# Altium schematic sheet Audio_Input_Digitial_Mic.SchDoc
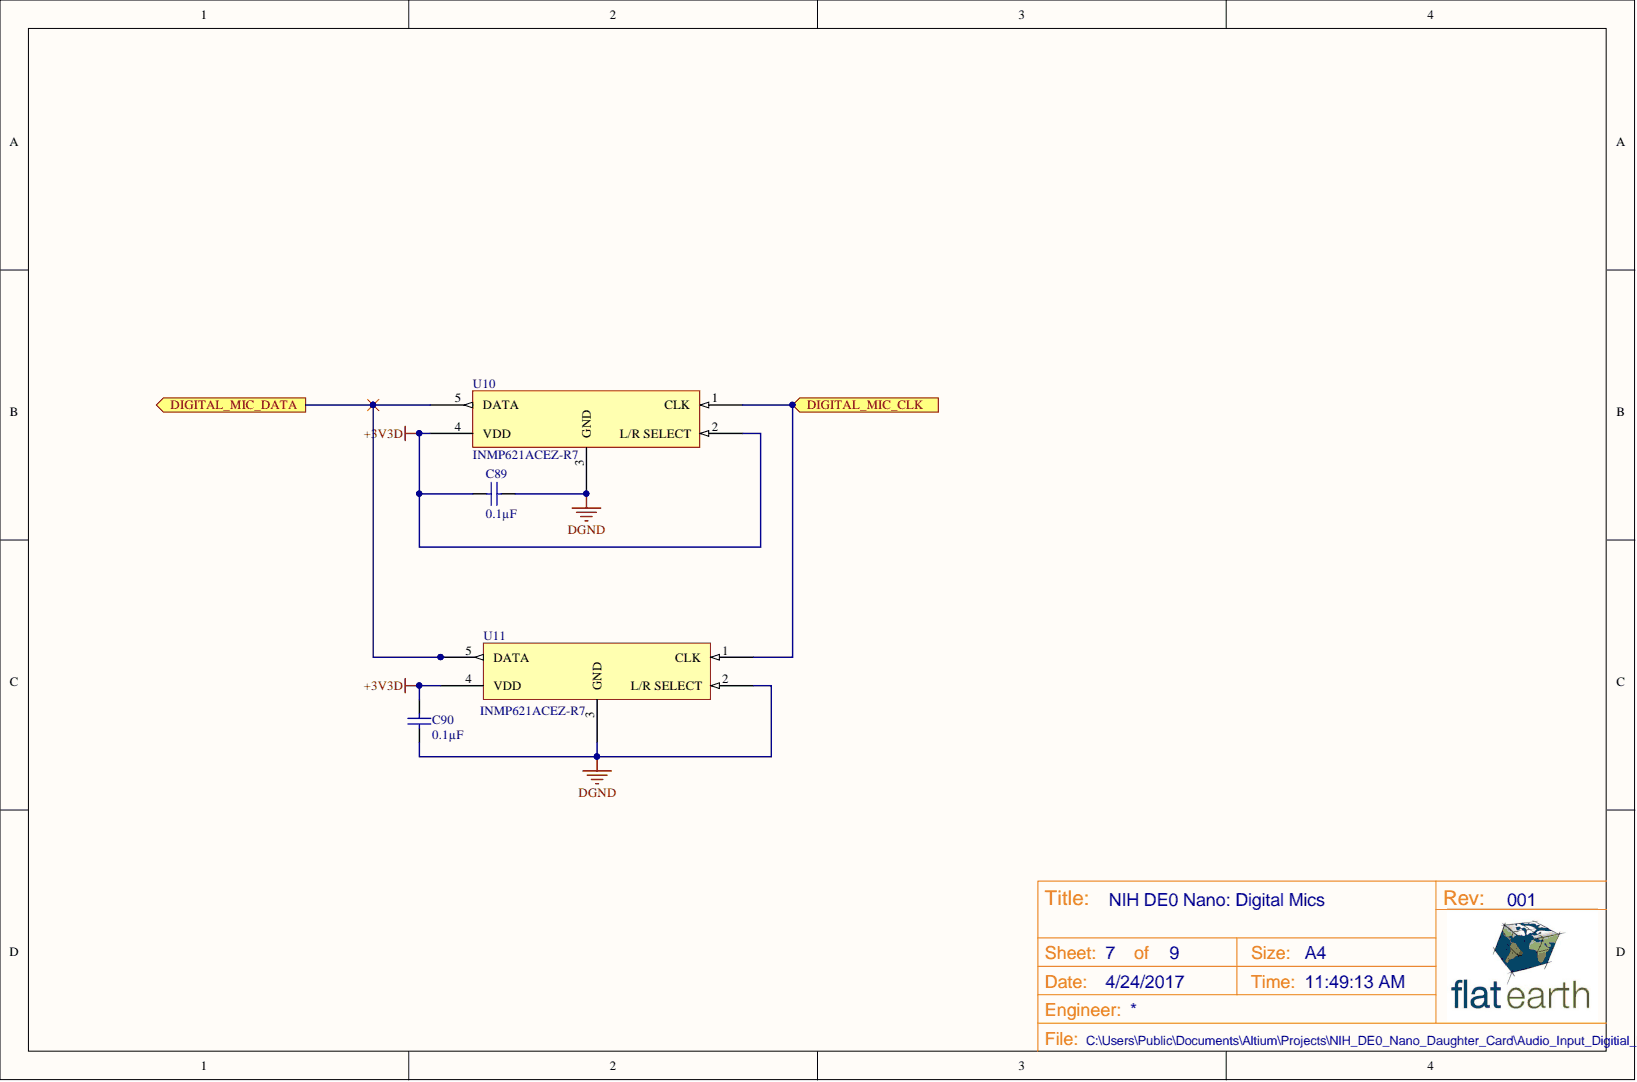
[diagram p0: Audio_Input_Digitial_Mic.SchDoc]

## Parts
C89  Capacitance: 0.1µF  [3-0603_L]  (hidden comment: C1608X7R1H104K)
C90  Capacitance: 0.1µF  [3-0603_L]  (hidden comment: C1608X7R1H104K)
U10  INMP621  Manufacturer Part Number: INMP621ACEZ-R7  [INMP621]
U11  INMP621  Manufacturer Part Number: INMP621ACEZ-R7  [INMP621]

## Ports
DIGITAL_MIC_CLK, DIGITAL_MIC_DATA

## Nets
+3V3D: C89.1 C90.2 U10.2(L/R_SELECT) U10.4(VDD) U11.4(VDD)
DGND: C89.2 C90.1 U10.3(GND) U11.2(L/R_SELECT) U11.3(GND)
DIGITAL_MIC_CLK: U10.1(CLK) U11.1(CLK) ; port DIGITAL_MIC_CLK
DIGITAL_MIC_DATA: U10.5(DATA) U11.5(DATA) ; port DIGITAL_MIC_DATA

## Library data of the parts
C89 C90 = C1608X7R1H104K: CAP CER 0.1UF 50V 10% X7R 0603; Manufacturer: TDK Corporation; Applications: General Purpose; Category: Capacitors; Component Kind: Standard; ComponentLink1Description: http://www.tdk.com/pdf/general_B11.pdf; ComponentLink1URL: http://www.tdk.com/pdf/general_B11.pdf; Features: -; Height - Seated (Max): -; Lead Spacing: -; Lead Style: -; Library Name: Schematic_Components.SchLib; Manufacturer Part Number: C1608X7R1H104K; Mounting Type: Surface Mount, MLCC; Operating Temperature: -55°C ~ 125°C; Package / Case: 0603 (1608 Metric); Packaging: Cut Tape (CT); Pricing 1: 10=0.048, 100=0.022, 250=0.018, 500=0.0154, 1000=0.012 (USD); Ratings: -; RoHS: RoHS Compliant; Size / Dimension: 0.063" L x 0.031" W (1.60mm x 0.80mm); Stock 1: 2442296; Supplier 1: Digi-Key; Supplier Part Number 1: 445-1314-1-ND; Temperature Coefficient: X7R; Thickness (Max): 0.037" (0.95mm); Tolerance: ±10%; Voltage - Rated: 50V
U10 U11 = INMP621: 45Hz ~ 20kHz Digital, PDM Microphone MEMS (Silicon) Omnidirectional (-46dB ±2dB @ 94dB SPL) Solder Pads; Manufacturer: InvenSense; Current - Supply: 1.6mA; Port Location: Bottom; S/N Ratio: 65dB; Seal Rating: None; Shape: Rectangular; Voltage Range: 1.62 ~ 3.63V; Category: Audio Products; Component Kind: Standard; ComponentLink1Description: https://www.invensense.com/wp-content/uploads/2015/02/INMP621.pdf; ComponentLink1URL: https://www.invensense.com/wp-content/uploads/2015/02/INMP621.pdf; Direction: Omnidirectional; Frequency Range: 45Hz ~ 20kHz; Height (Max): 1.10mm; Impedance: -; Output Type: Digital, PDM; Packaging: Cut Tape (CT); Part Status: Active; Pricing 1: 1=2.88, 10=2.491, 25=2.2292, 50=1.9668, 100=1.9012, 250=1.83568, 500=1.77012 (USD); RoHS: RoHS Compliant; Sensitivity: -46dB ±2dB @ 94dB SPL; Size / Dimension: 4.00mm L x 3.00mm W; Stock 1: 1211; Supplier 1: Digi-Key; Supplier Part Number 1: 1428-1018-1-ND; Termination: Solder Pads; Type: MEMS (Silicon); Voltage - Rated: -; Library Name: NIH_DE0_Nano_Daughter_Card_Library.SchLib
